# Altium schematic sheet Leveshifter_Shield.SchDoc
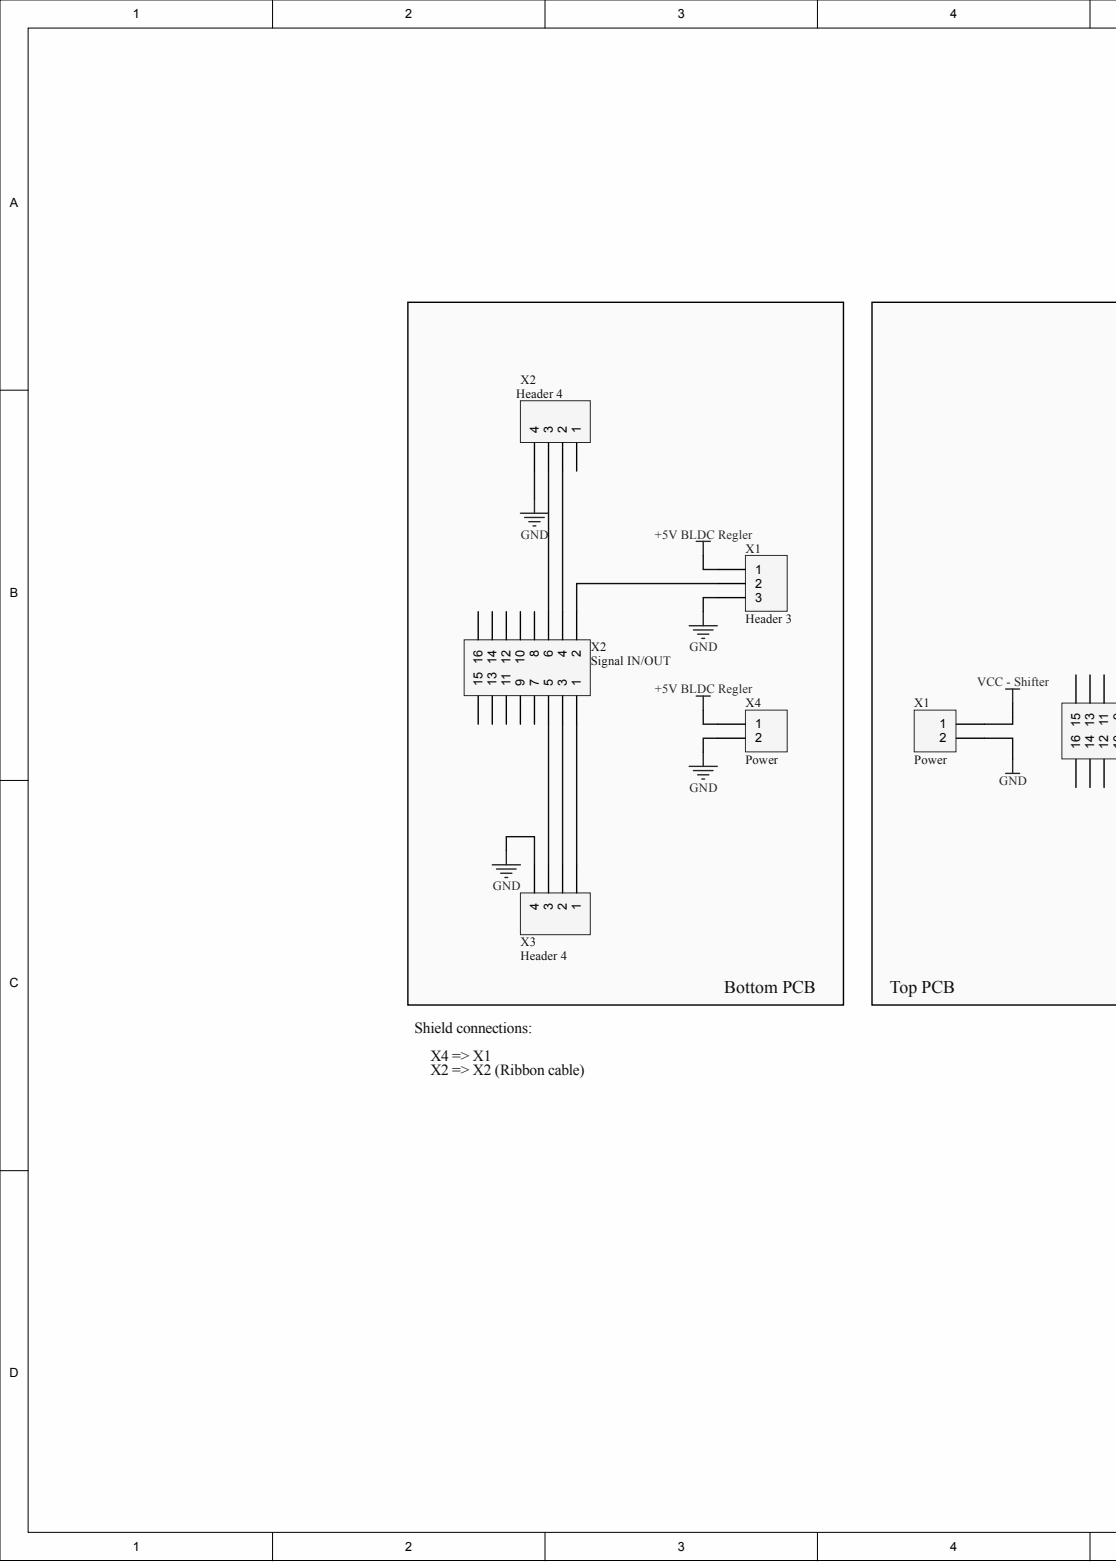
[diagram p0: Leveshifter_Shield.SchDoc, left]
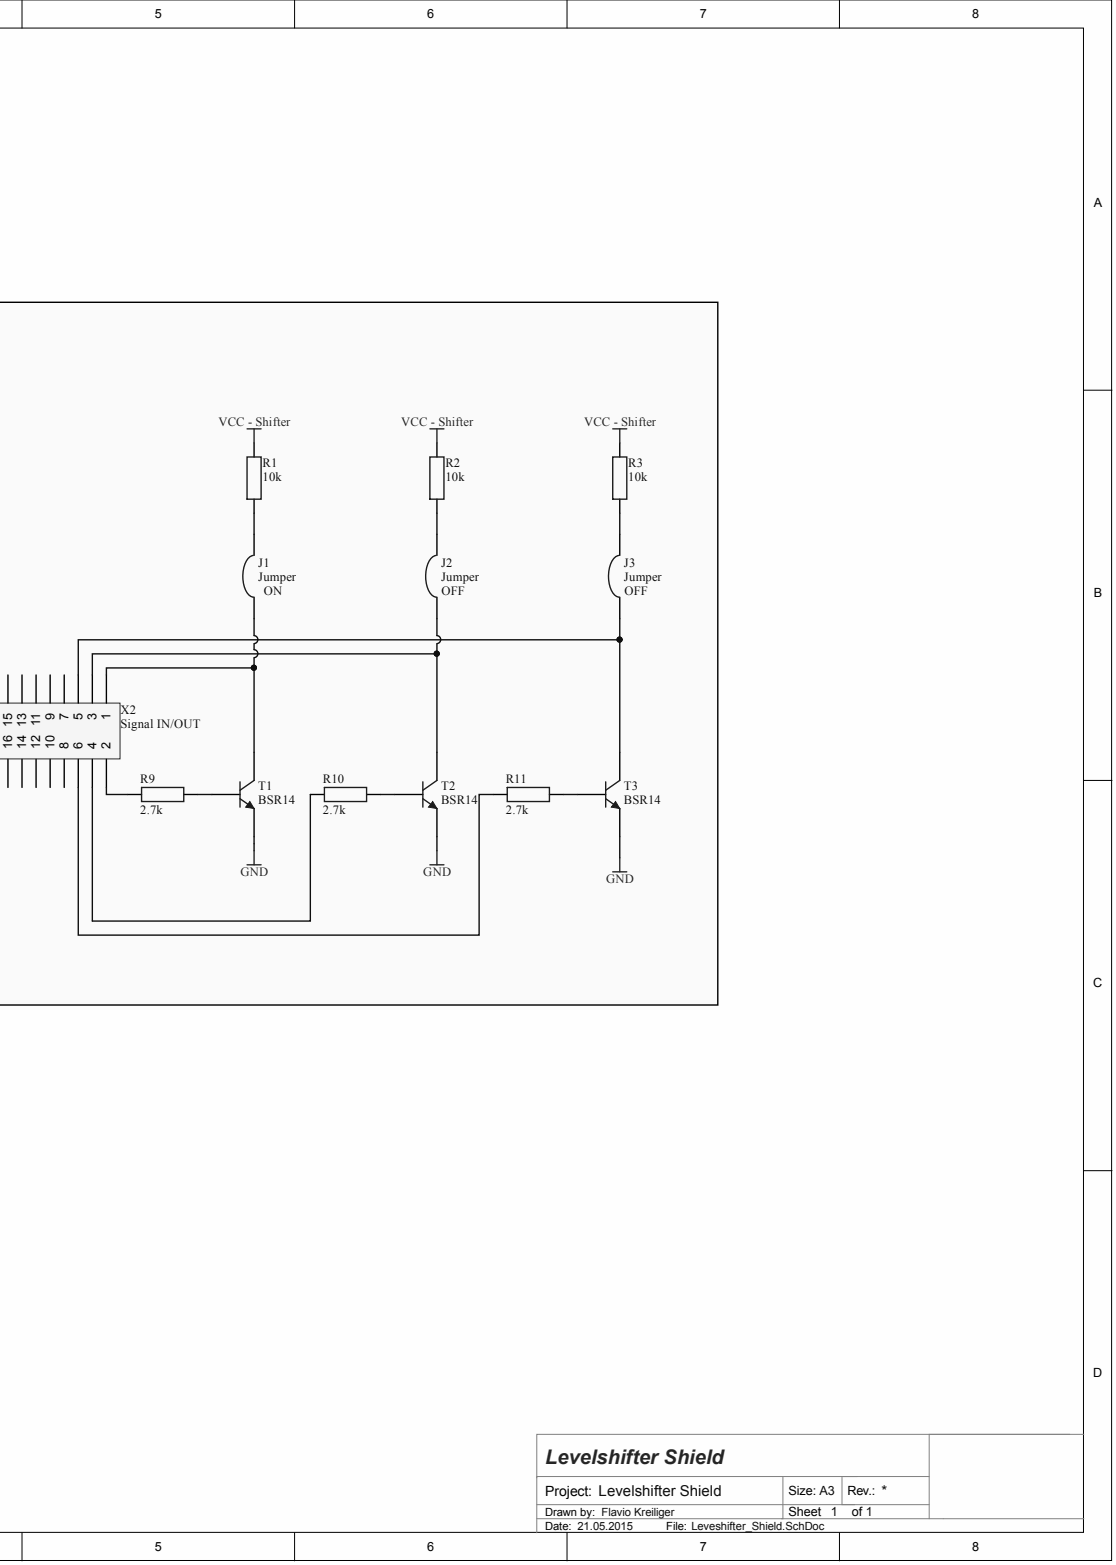
[diagram p1: Leveshifter_Shield.SchDoc, right]

## Parts
J1  Jumper  [Jumper]  (jumper)
J2  Jumper  [Jumper]  (jumper)
J3  Jumper  [Jumper]  (jumper)
R1  10k  [0805]
R2  10k  [0805]
R3  10k  [0805]
R9  2.7k  [0805]
R10  2.7k  [0805]
R11  2.7k  [0805]
T1  BSR14  [SOT-23A_M]
T2  BSR14  [SOT-23A_M]
T3  BSR14  [SOT-23A_M]
X1  Power  [HDR1X2]
X1  Header 3  [HDR1X3]
X2  Signal IN/OUT  [HDR2X8]
X2  Signal IN/OUT  [HDR2X8]
X2  Header 4  [HDR1X4]
X3  Header 4  [HDR1X4]
X4  Power  [HDR1X2]

## Nets
+5V BLDC REGLER: X1.1 X4.1
GND: T1.2 T2.2 T3.2 X1.2 X1.3 X2.4 X3.4 X4.2
VCC - SHIFTER: R1.2 R2.2 R3.2 X1.1
NetJ1_1: J1.1 T1.3 X2.1
NetJ1_2: J1.2 R1.1
NetJ2_1: J2.1 T2.3 X2.3
NetJ2_2: J2.2 R2.1
NetJ3_1: J3.1 T3.3 X2.5
NetJ3_2: J3.2 R3.1
NetR9_1: R9.1 X2.2
NetR9_2: R9.2 T1.1
NetR10_1: R10.1 X2.4
NetR10_2: R10.2 T2.1
NetR11_1: R11.1 X2.6
NetR11_2: R11.2 T3.1
NetX1_2: X1.2 X2.2
NetX2_1: X2.1 X3.1
NetX2_2: X2.2 X2.4
NetX2_3: X2.3 X2.6 X3.2
NetX2_5: X2.5 X3.3

Not connected: X2 7 8 9 10 11 12 13 14 15 16

## Library data of the parts
J1-J3 = Jumper: Wire link; Library Name: Level_shifter.SchLib
R1-R3 R9-R11 = R?: Normaler Widerstand; Component Kind: Standard; Library Name: DEVICE_HSLU.SchLib
T1-T3 = BC817-25: NPN General Purpose Amplifier; Component Kind: Standard; ComponentLink1Description: Manufacturer Link; ComponentLink1URL: http://www.fairchildsemi.com/; ComponentLink2Description: Discontinuation Notice; ComponentLink2URL: http://www.fairchildsemi.com/obsolete/obs.jsp; DatasheetVersion: 1997; LatestRevisionDate: 20-Nov-2009; LatestRevisionNote: IPC-7351 Footprint Added.; Library Name: FSC Discrete BJT.IntLib; PackageDescription: 3-Pin SOT-23 Package 0.95 mm Pitch, 2.3 mm Lead Span; PackageReference: SOT-23A; PackageVersion: Sep-1998; Published: 8-Jun-2000; Publisher: Altium Limited
X1 X4 = Header 2: Header, 2-Pin; Component Kind: Standard; LatestRevisionDate: 17-Jul-2002; LatestRevisionNote: Re-released for DXP Platform.; Library Name: Miscellaneous Connectors.IntLib; Signal Integrity: Connector; Publisher: Altium Limited
X1 = Header 3: Header, 3-Pin; Component Kind: Standard; LatestRevisionDate: 17-Jul-2002; LatestRevisionNote: Re-released for DXP Platform.; Library Name: Miscellaneous Connectors.IntLib; Signal Integrity: Connector; Publisher: Altium Limited
X2 X2 = Header 8X2: Header, 8-Pin, Dual row; Component Kind: Standard; LatestRevisionDate: 17-Jul-2002; LatestRevisionNote: Re-released for DXP Platform.; Library Name: Miscellaneous Connectors.IntLib; Signal Integrity: Connector; Publisher: Altium Limited
X2 X3 = Header 4: Header, 4-Pin; Component Kind: Standard; LatestRevisionDate: 17-Jul-2002; LatestRevisionNote: Re-released for DXP Platform.; Library Name: Miscellaneous Connectors.IntLib; Signal Integrity: Connector; Publisher: Altium Limited
